# Altium schematic sheet Connectors.SchDoc
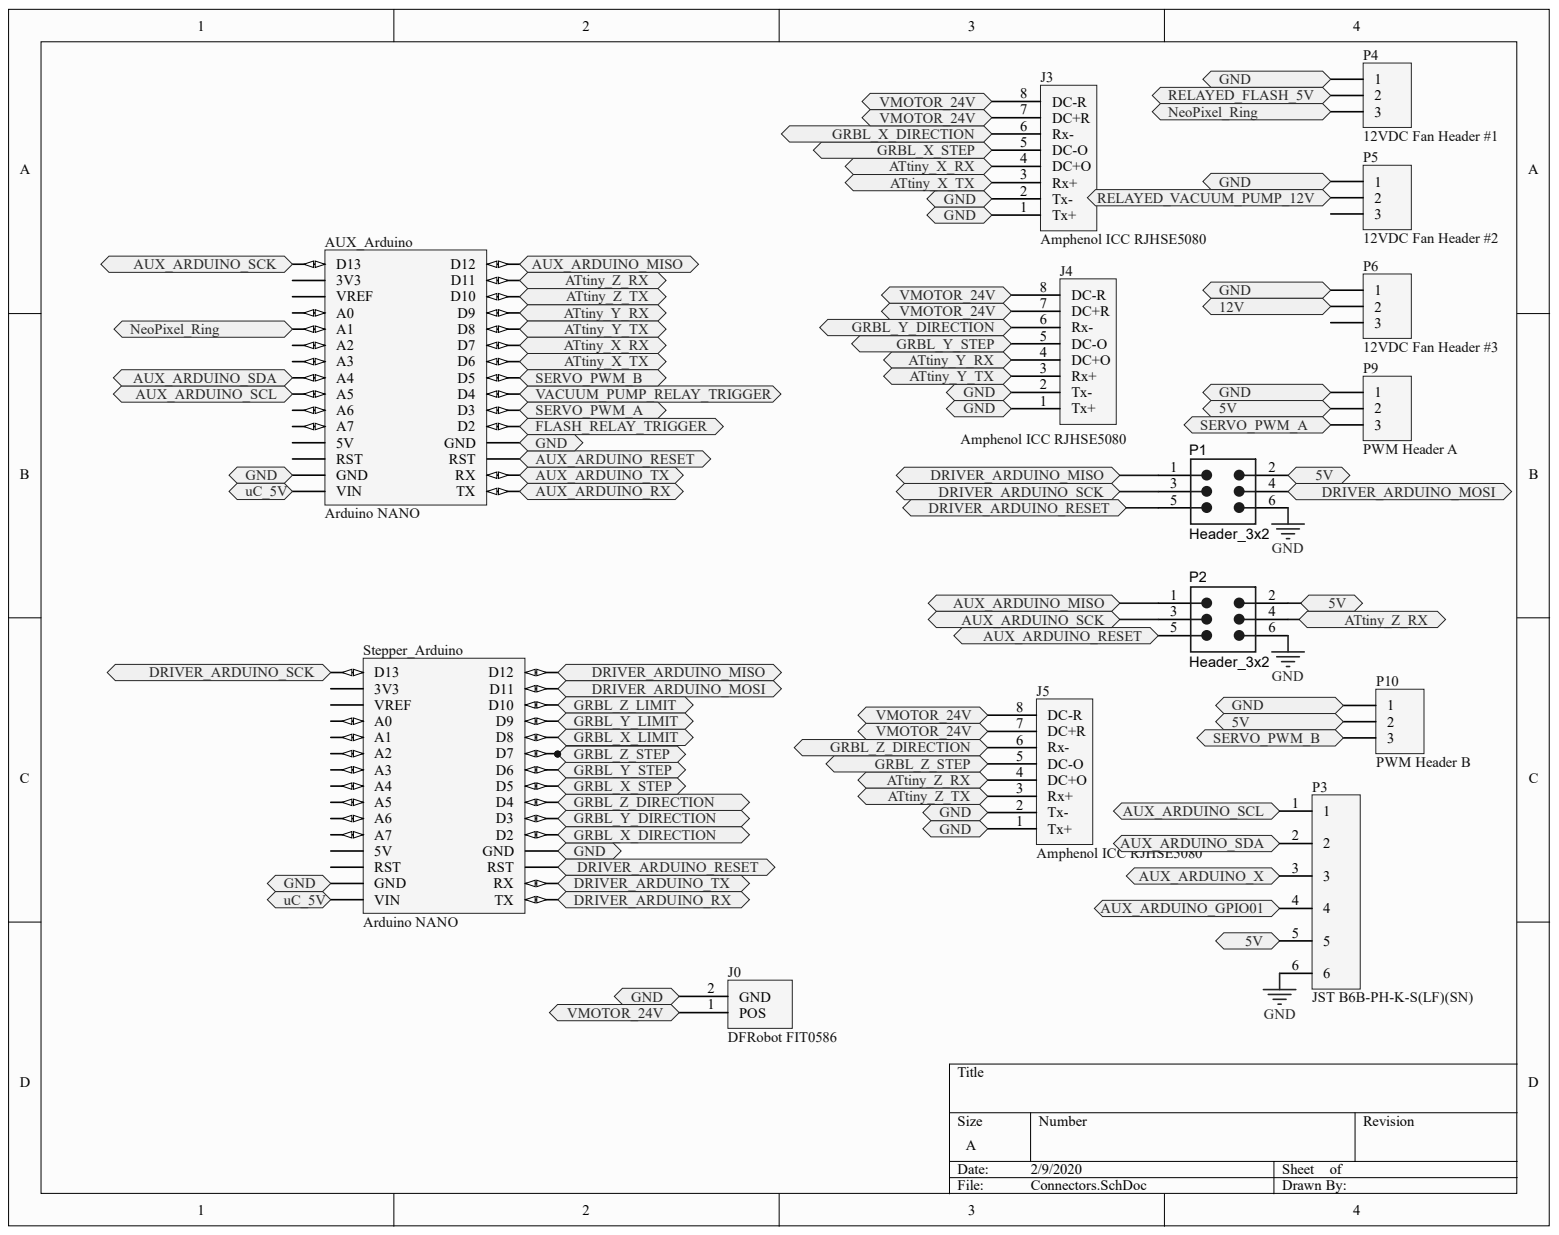
[diagram p0: Connectors.SchDoc]

## Parts
AUX_Arduino  Arduino NANO  [PCB-vv8a1hzx923o7t0c3sdz-1]
J0  DFRobot FIT0586  [PCB-qcnpj6p0hbzpl0cja5hs-1]
J3  Amphenol ICC RJHSE5080  [PCB-qwl9qjksk46hanrttlea-1]
J4  Amphenol ICC RJHSE5080  Manufacturer Part Number: RJHSE5080  [PCB-qwl9qjksk46hanrttlea-1]
J5  Amphenol ICC RJHSE5080  [PCB-qwl9qjksk46hanrttlea-1]
P1  Header_3x2  [2x3P]
P2  Header_3x2  [2x3P]
P3  JST B6B-PH-K-S(LF)(SN)  Manufacturer Part Number: B6B-PH-K-S(LF)(SN)  [PCB-zgutn5khdenyh1r5q6qg-1]
P4  12VDC Fan Header #1  [10X03MTA]
P5  12VDC Fan Header #2  [10X03MTA]
P6  12VDC Fan Header #3  [10X03MTA]
P9  PWM Header A  [10X03MTA]
P10  PWM Header B  [10X03MTA]
Stepper_Arduino  Arduino NANO  [PCB-vv8a1hzx923o7t0c3sdz-1]

## Ports
5V, 12V, ATTINY_X_RX, ATTINY_X_TX, ATTINY_Y_RX, ATTINY_Y_TX, ATTINY_Z_RX, ATTINY_Z_TX, AUX_ARDUINO_GPIO01, AUX_ARDUINO_MISO, AUX_ARDUINO_RESET, AUX_ARDUINO_RX, AUX_ARDUINO_SCK, AUX_ARDUINO_SCL, AUX_ARDUINO_SDA, AUX_ARDUINO_TX, AUX_ARDUINO_X, DRIVER_ARDUINO_MISO, DRIVER_ARDUINO_MOSI, DRIVER_ARDUINO_RESET, DRIVER_ARDUINO_RX, DRIVER_ARDUINO_SCK, DRIVER_ARDUINO_TX, FLASH_RELAY_TRIGGER, GND, GRBL_X_DIRECTION, GRBL_X_LIMIT, GRBL_X_STEP, GRBL_Y_DIRECTION, GRBL_Y_LIMIT, GRBL_Y_STEP, GRBL_Z_DIRECTION, GRBL_Z_LIMIT, GRBL_Z_STEP, NEOPIXEL_RING, RELAYED_FLASH_5V, RELAYED_VACUUM_PUMP_12V, SERVO_PWM_A, SERVO_PWM_B, UC_5V, VACUUM_PUMP_RELAY_TRIGGER, VMOTOR_24V

## Nets
ATTINY_X_RX: AUX_Arduino.10(D7) J3.4(DC+O) ; port ATTINY_X_RX
ATTINY_X_TX: AUX_Arduino.9(D6) J3.3(Rx+) ; port ATTINY_X_TX
ATTINY_Y_RX: AUX_Arduino.12(D9) J4.4(DC+O) ; port ATTINY_Y_RX
ATTINY_Y_TX: AUX_Arduino.11(D8) J4.3(Rx+) ; port ATTINY_Y_TX
ATTINY_Z_RX: AUX_Arduino.14(D11) J5.4(DC+O) P2.4 ; port ATTINY_Z_RX
ATTINY_Z_TX: AUX_Arduino.13(D10) J5.3(Rx+) ; port ATTINY_Z_TX
NEOPIXEL_RING: AUX_Arduino.20(A1) P4.3 ; port NEOPIXEL_RING
UC_5V: AUX_Arduino.30(VIN) Stepper_Arduino.30(VIN) ; port UC_5V
5V: P1.2 P2.2 P3.5 P9.2 P10.2 ; port 5V
12V: P6.2 ; port 12V
AUX_ARDUINO_GPIO01: P3.4 ; port AUX_ARDUINO_GPIO01
AUX_ARDUINO_MISO: AUX_Arduino.15(D12) P2.1 ; port AUX_ARDUINO_MISO
AUX_ARDUINO_RESET: AUX_Arduino.3(RST) P2.5 ; port AUX_ARDUINO_RESET
AUX_ARDUINO_RX: AUX_Arduino.1(TX) ; port AUX_ARDUINO_RX
AUX_ARDUINO_SCK: AUX_Arduino.16(D13) P2.3 ; port AUX_ARDUINO_SCK
AUX_ARDUINO_SCL: AUX_Arduino.24(A5) P3.1 ; port AUX_ARDUINO_SCL
AUX_ARDUINO_SDA: AUX_Arduino.23(A4) P3.2 ; port AUX_ARDUINO_SDA
AUX_ARDUINO_TX: AUX_Arduino.2(RX) ; port AUX_ARDUINO_TX
AUX_ARDUINO_X: P3.3 ; port AUX_ARDUINO_X
DRIVER_ARDUINO_MISO: P1.1 Stepper_Arduino.15(D12) ; port DRIVER_ARDUINO_MISO
DRIVER_ARDUINO_MOSI: P1.4 Stepper_Arduino.14(D11) ; port DRIVER_ARDUINO_MOSI
DRIVER_ARDUINO_RESET: P1.5 Stepper_Arduino.3(RST) ; port DRIVER_ARDUINO_RESET
DRIVER_ARDUINO_RX: Stepper_Arduino.1(TX) ; port DRIVER_ARDUINO_RX
DRIVER_ARDUINO_SCK: P1.3 Stepper_Arduino.16(D13) ; port DRIVER_ARDUINO_SCK
DRIVER_ARDUINO_TX: Stepper_Arduino.2(RX) ; port DRIVER_ARDUINO_TX
FLASH_RELAY_TRIGGER: AUX_Arduino.5(D2) ; port FLASH_RELAY_TRIGGER
GND: AUX_Arduino.4(GND) AUX_Arduino.29(GND) J0.2 J3.1(Tx+) J3.2(Tx-) J4.1(Tx+) J4.2(Tx-) J5.1(Tx+) J5.2(Tx-) P1.6 P2.6 P3.6 P4.1 P5.1 P6.1 P9.1 P10.1 Stepper_Arduino.4(GND) Stepper_Arduino.29(GND) ; port GND
GRBL_X_DIRECTION: J3.6(Rx-) Stepper_Arduino.5(D2) ; port GRBL_X_DIRECTION
GRBL_X_LIMIT: Stepper_Arduino.11(D8) ; port GRBL_X_LIMIT
GRBL_X_STEP: J3.5(DC-O) Stepper_Arduino.8(D5) ; port GRBL_X_STEP
GRBL_Y_DIRECTION: J4.6(Rx-) Stepper_Arduino.6(D3) ; port GRBL_Y_DIRECTION
GRBL_Y_LIMIT: Stepper_Arduino.12(D9) ; port GRBL_Y_LIMIT
GRBL_Y_STEP: J4.5(DC-O) Stepper_Arduino.9(D6) ; port GRBL_Y_STEP
GRBL_Z_DIRECTION: J5.6(Rx-) Stepper_Arduino.7(D4) ; port GRBL_Z_DIRECTION
GRBL_Z_LIMIT: Stepper_Arduino.13(D10) ; port GRBL_Z_LIMIT
GRBL_Z_STEP: J5.5(DC-O) Stepper_Arduino.10(D7) ; port GRBL_Z_STEP
RELAYED_FLASH_5V: P4.2 ; port RELAYED_FLASH_5V
RELAYED_VACUUM_PUMP_12V: P5.2 ; port RELAYED_VACUUM_PUMP_12V
SERVO_PWM_A: AUX_Arduino.6(D3) P9.3 ; port SERVO_PWM_A
SERVO_PWM_B: AUX_Arduino.8(D5) P10.3 ; port SERVO_PWM_B
VACUUM_PUMP_RELAY_TRIGGER: AUX_Arduino.7(D4) ; port VACUUM_PUMP_RELAY_TRIGGER
VMOTOR_24V: J0.1 J3.7(DC+R) J3.8(DC-R) J4.7(DC+R) J4.8(DC-R) J5.7(DC+R) J5.8(DC-R) ; port VMOTOR_24V

Not connected: AUX_Arduino 17(3V3) 18(VREF) 19(A0) 21(A2) 22(A3) 25(A6) 26(A7) 27(5V) 28(RST); P5 3; P6 3; Stepper_Arduino 17(3V3) 18(VREF) 19(A0) 20(A1) 21(A2) 22(A3) 23(A4) 24(A5) 25(A6) 26(A7) 27(5V) 28(RST)

## Library data of the parts
AUX_Arduino Stepper_Arduino = CMP-f1bd39841b322e7a-67: Nano 3.0 Atmel Atmega 328 MCU board; Manufacturer Part Number: A000005; Core Architecture: AVR; Component Kind: Standard; Library Name: CommunityVault; OctopartID: f1bd39841b322e7a; REACH SVHC Compliance: No SVHC; RoHS: Compliant
J0 = CMP-9e1652bfce1041d1-5: High Quality Gold Plated XT30 Male Female Bullet Connector; Component Kind: Standard; Library Name: CommunityVault
J3-J5 = CMP-cf9c03f44717105b-1: Connector; Modular Jack; 8; Phosphor Bronze; Gold Over Nickel; 0.62 in.; Lead-Free Status: Lead Free; Number of Contacts: 8; Shielding: No; Voltage Rating (DC): 125 V; Color: Black; Component Kind: Standard; Contact Material: Phosphor Bronze; Contact Plating: Gold over Nickel, Gold; Library Name: CommunityVault; Number of Positions: 8; OctopartID: cf9c03f44717105b; Octopart URL: https://octopart.com/rjhse5080-amphenol+icc-90353133; Orientation: Right Angle; Packaging: Tray; REACH SVHC Compliance: No SVHC; RoHS: Compliant; Size-Height: 13.2 mm; Size-Length: 15.7 mm
  J3 J5: Manufacturer Part Number: RJHSE5080
P1 P2 = CMP-18f2d1e5a96ec735-3: Conn Unshrouded Header HDR 6 POS 2.54mm Solder ST Thru-Hole Automotive Carton; Manufacturer Part Number: 826632-3; Number of Contacts: 6; Component Kind: Standard; Contact Material: Phosphor Bronze; Housing Color: Green; Library Name: CommunityVault; Lifecycle Status: Active; Mounting Style: PCB; OctopartID: 18f2d1e5a96ec735; Orientation: Vertical; REACH SVHC Compliance: No SVHC; Seller Landing  Url: https://octopart-clicks.com/click/track?country=US&ak=8157f166&sig=0f290fb&sid=5489&ppid=1938682&vpid=271695964&ct=offers
P3 = CMP-97605e697e2e48a7-2: PH Series 6 Position 2 mm Pitch Through Hole Crimp Top Entry Shrouded Header; Contact Resistance: 10.0 mΩ; Current Rating: 2.00 A; Gender: Male; Insulation Resistance: 1.00 MΩ; Lead-Free Status: Lead Free; Number of Contacts: 6; Pin Pitch: 2.00 mm; Voltage Rating (AC): 100 V; Component Kind: Standard; Contact Material: Brass; Contact Plating: Tin; Housing Color: Natural; Housing Material: Nylon; Library Name: CommunityVault; Mounting Style: Through Hole; Number of Positions: 6; Number of Rows: 1; OctopartID: 97605e697e2e48a7; Octopart URL: http://octopart.com/b6b-ph-k-s%28lf%29%28sn%29-jst-248872; Packaging: Bulk; REACH SVHC Compliance: No SVHC; RoHS: Compliant; Size-Height: 6.00 mm; Size-Length: 13.9 mm; Size-Width: 4.50 mm
P4-P6 P9 P10 = CMP-640264-14: Socket; wire-board; male; PIN:3; 2.54mm; THT; KK 254; tinned; Manufacturer Part Number: 640456-3; Current Rating: 4.0 A; ELV Compliant: Compliant; Lead-Free Status: Lead Free; Mounting Angle: 180.0 °; Number of Contacts: 3; Pin Pitch: 0.00254 m; Shrouded: No; Voltage Rating (AC): 250.0 V; Color: White; Component Kind: Standard; Contact Material: Copper Alloy; Contact Plating: Tin; Flammability Rating: UL 94 V-0; Gender: Male; Housing Color: Natural; Housing Material: Polyester; Library Name: CommunityVault; Lifecycle Status: Active; Number of Positions: 3; Number of Rows: 1; OctopartID: cb1400db4dd814d3; Octopart Url: https://octopart.com/640456-3-te+connectivity-46506922; Orientation: Vertical; Packaging: Bag; REACH SVHC Compliance: No SVHC; RoHS: Compliant; Size-Height: 0.007874 m; Size-Length: 0.00762 m; Size-Width: 0.005715 m
